# Altium schematic sheet 3_AVIDEO.SchDoc(3_AVIDEO)
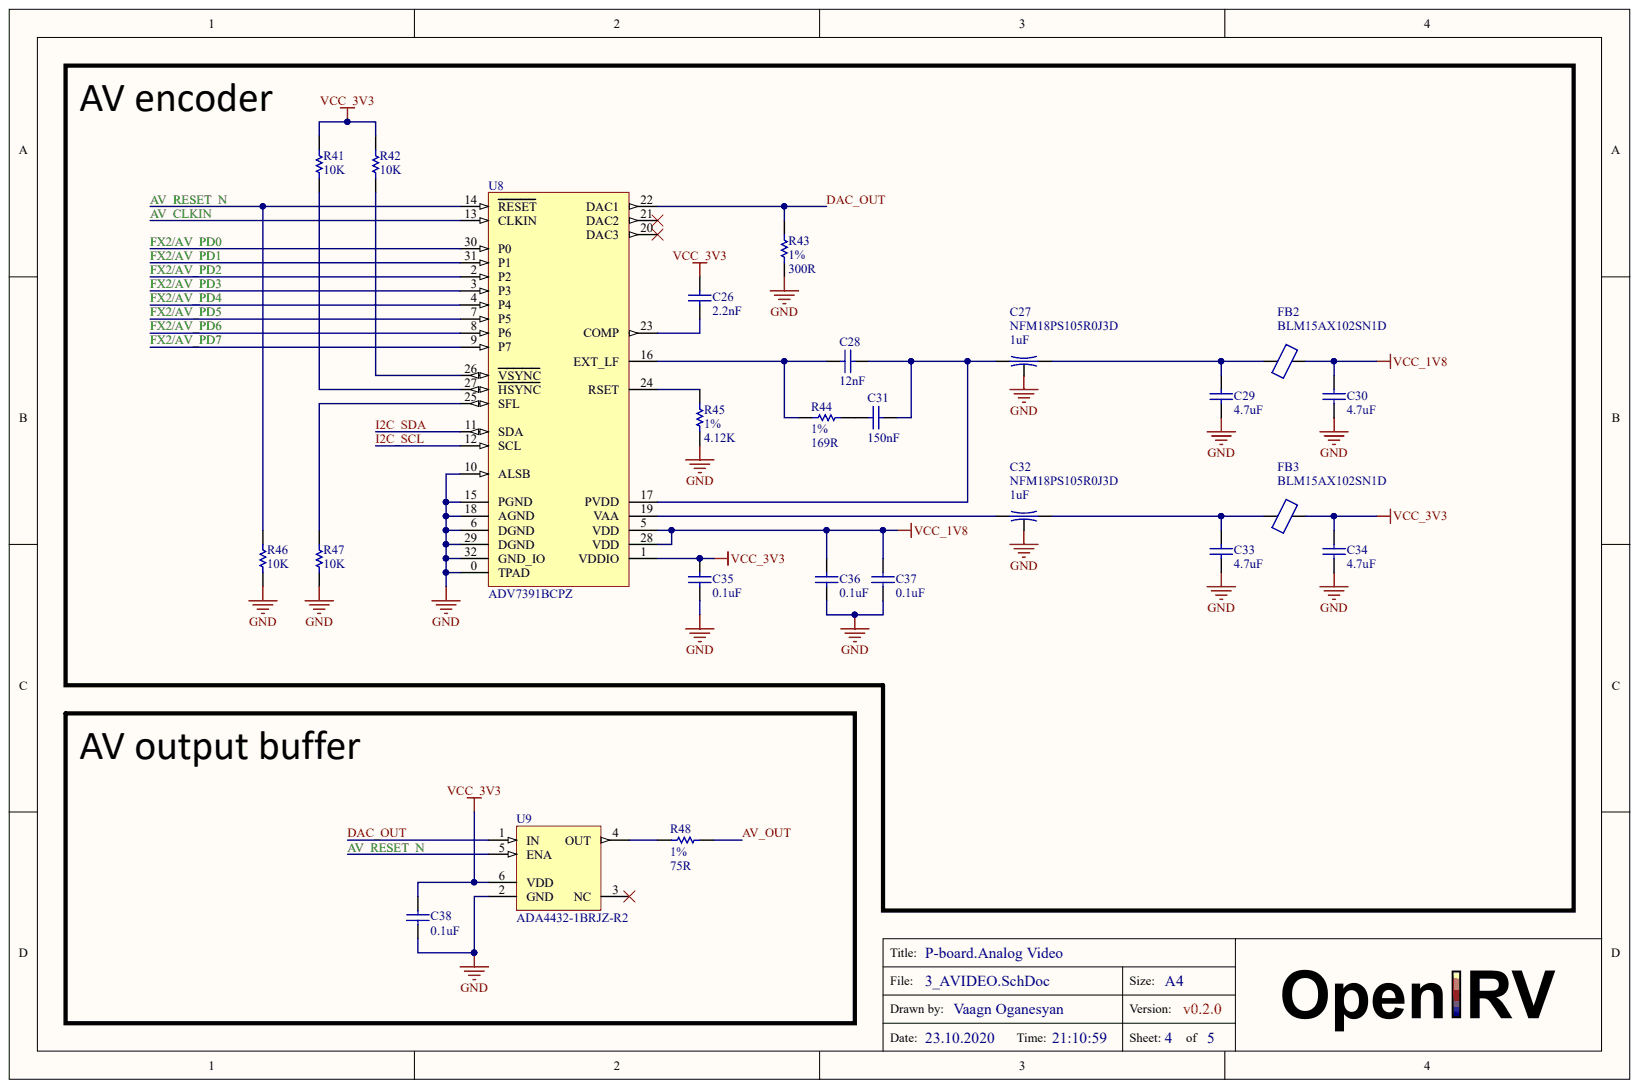
[diagram p0: 3_AVIDEO.SchDoc]

## Parts
C26  2.2nF  [C0603[1608]]
C27  1uF  ManufacturerPartNumber: NFM18PS105R0J3D  [NFM18PS]
C28  12nF  [C0603[1608]]
C29  4.7uF  [C0402[1005]]
C30  4.7uF  [C0402[1005]]
C31  150nF  [C0603[1608]]
C32  1uF  ManufacturerPartNumber: NFM18PS105R0J3D  [NFM18PS]
C33  4.7uF  [C0402[1005]]
C34  4.7uF  [C0402[1005]]
C35  0.1uF  [C0402[1005]]
C36  0.1uF  [C0402[1005]]
C37  0.1uF  [C0402[1005]]
C38  0.1uF  [C0402[1005]]
FB2  BLM15AX102SN1D  [L0402[1005]]
FB3  BLM15AX102SN1D  [L0402[1005]]
R41  10K  [R0402[1005]]
R42  10K  [R0402[1005]]
R43  300R  Tolerance: 1%  [R0603[1608]]
R44  169R  Tolerance: 1%  [R0603[1608]]
R45  4.12K  Tolerance: 1%  [R0603[1608]]
R46  10K  [R0402[1005]]
R47  10K  [R0402[1005]]
R48  75R  Tolerance: 1%  [R0603[1608]]
U8  ADV7391BCPZ  [ADV7391BCPZ]
U9  ADA4432-1BRJZ-R2  [ADA4432-1BRJZ-R2]

## Nets
GND: C27.2 C29.2 C30.2 C32.2 C33.2 C34.2 C35.1 C36.1 C37.1 C38.1 R43.2 R45.2 R46.2 R47.1 U8.0(TPAD) U8.6(DGND) U8.10(ALSB) U8.15(PGND) U8.18(AGND) U8.29(DGND) U8.32(GND_IO) U9.2(GND)
VCC_1V8: C30.1 C36.2 C37.2 FB2.2 U8.5(VDD) U8.28(VDD)
VCC_3V3: C26.2 C34.1 C35.2 C38.2 FB3.2 R41.1 R42.1 U8.1(VDDIO) U9.6(VDD)
AV_CLKIN: U8.13(CLKIN)
AV_OUT: R48.2
AV_RESET_N: R46.1 U8.14(RESET) U9.5(ENA)
DAC_OUT: R43.1 U8.22(DAC1) U9.1(IN)
FX2/AV_PD0: U8.30(P0)
FX2/AV_PD1: U8.31(P1)
FX2/AV_PD2: U8.2(P2)
FX2/AV_PD3: U8.3(P3)
FX2/AV_PD4: U8.4(P4)
FX2/AV_PD5: U8.7(P5)
FX2/AV_PD6: U8.8(P6)
FX2/AV_PD7: U8.9(P7)
I2C_SCL: U8.12(SCL)
I2C_SDA: U8.11(SDA)
NetC26_1: C26.1 U8.23(COMP)
NetC27_1: C27.1 C28.1 C31.1 U8.17(PVDD)
NetC27_3: C27.3 C29.1 FB2.1
NetC28_2: C28.2 R44.1 U8.16(EXT_LF)
NetC31_2: C31.2 R44.2
NetC32_1: C32.1 U8.19(VAA)
NetC32_3: C32.3 C33.1 FB3.1
NetR41_2: R41.2 U8.27(HSYNC)
NetR42_2: R42.2 U8.26(VSYNC)
NetR45_1: R45.1 U8.24(RSET)
NetR47_2: R47.2 U8.25(SFL)
NetR48_1: R48.1 U9.4(OUT)

Not connected: U8 20(DAC3) 21(DAC2); U9 3(NC)

## Library data of the parts
C26 C28 C31 C35-C38 = CAP: Dielectric: X7R; Tolerance: 10%; Voltage: 50V; Component Kind: Standard; Library Name: general.IntLib; Mounting: SMD
  C26: Value: 2.2nF
  C28: Value: 12nF
  C31: Value: 150nF
  C35-C38: Value: 0.1uF
C27 C32 = 3T_CAP: Current: 2A; Tolerance: 20%; Voltage: 6.3V; Component Kind: Standard; Library Name: general.IntLib; Mounting: SMD; Value: 1uF
C29 C30 C33 C34 = CAP: Dielectric: X5R; Tolerance: 10%; Voltage: 6.3V; Component Kind: Standard; Library Name: general.IntLib; Mounting: SMD; Value: 4.7uF
FB2 FB3 = Ferrite_bead: Current: 0.35A; DC resistance: 0R49; Impedance: 1000R; Component Kind: Standard; Library Name: general.IntLib; ManufacturerPartNumber: BLM15AX102SN1D; Mounting: SMD
R41 R42 R46 R47 = RES: Power: 0.06W; Tolerance: 5%; Component Kind: Standard; Library Name: general.IntLib; Mounting: SMD; Value: 10K
R43-R45 R48 = RES: Power: 0.1W; Component Kind: Standard; Library Name: general.IntLib; Mounting: SMD
  R43: Value: 300R
  R44: Value: 169R
  R45: Value: 4.12K
  R48: Value: 75R
U8 = ADV7391BCPZ: 10-bit SD/HD Video Encoder; Component Kind: Standard; Library Name: general.IntLib
U9 = ADA4432-1BRJZ-R2: Component Kind: Standard; Library Name: general.IntLib
